# Altium schematic sheet External_Board_2mode.SchDoc
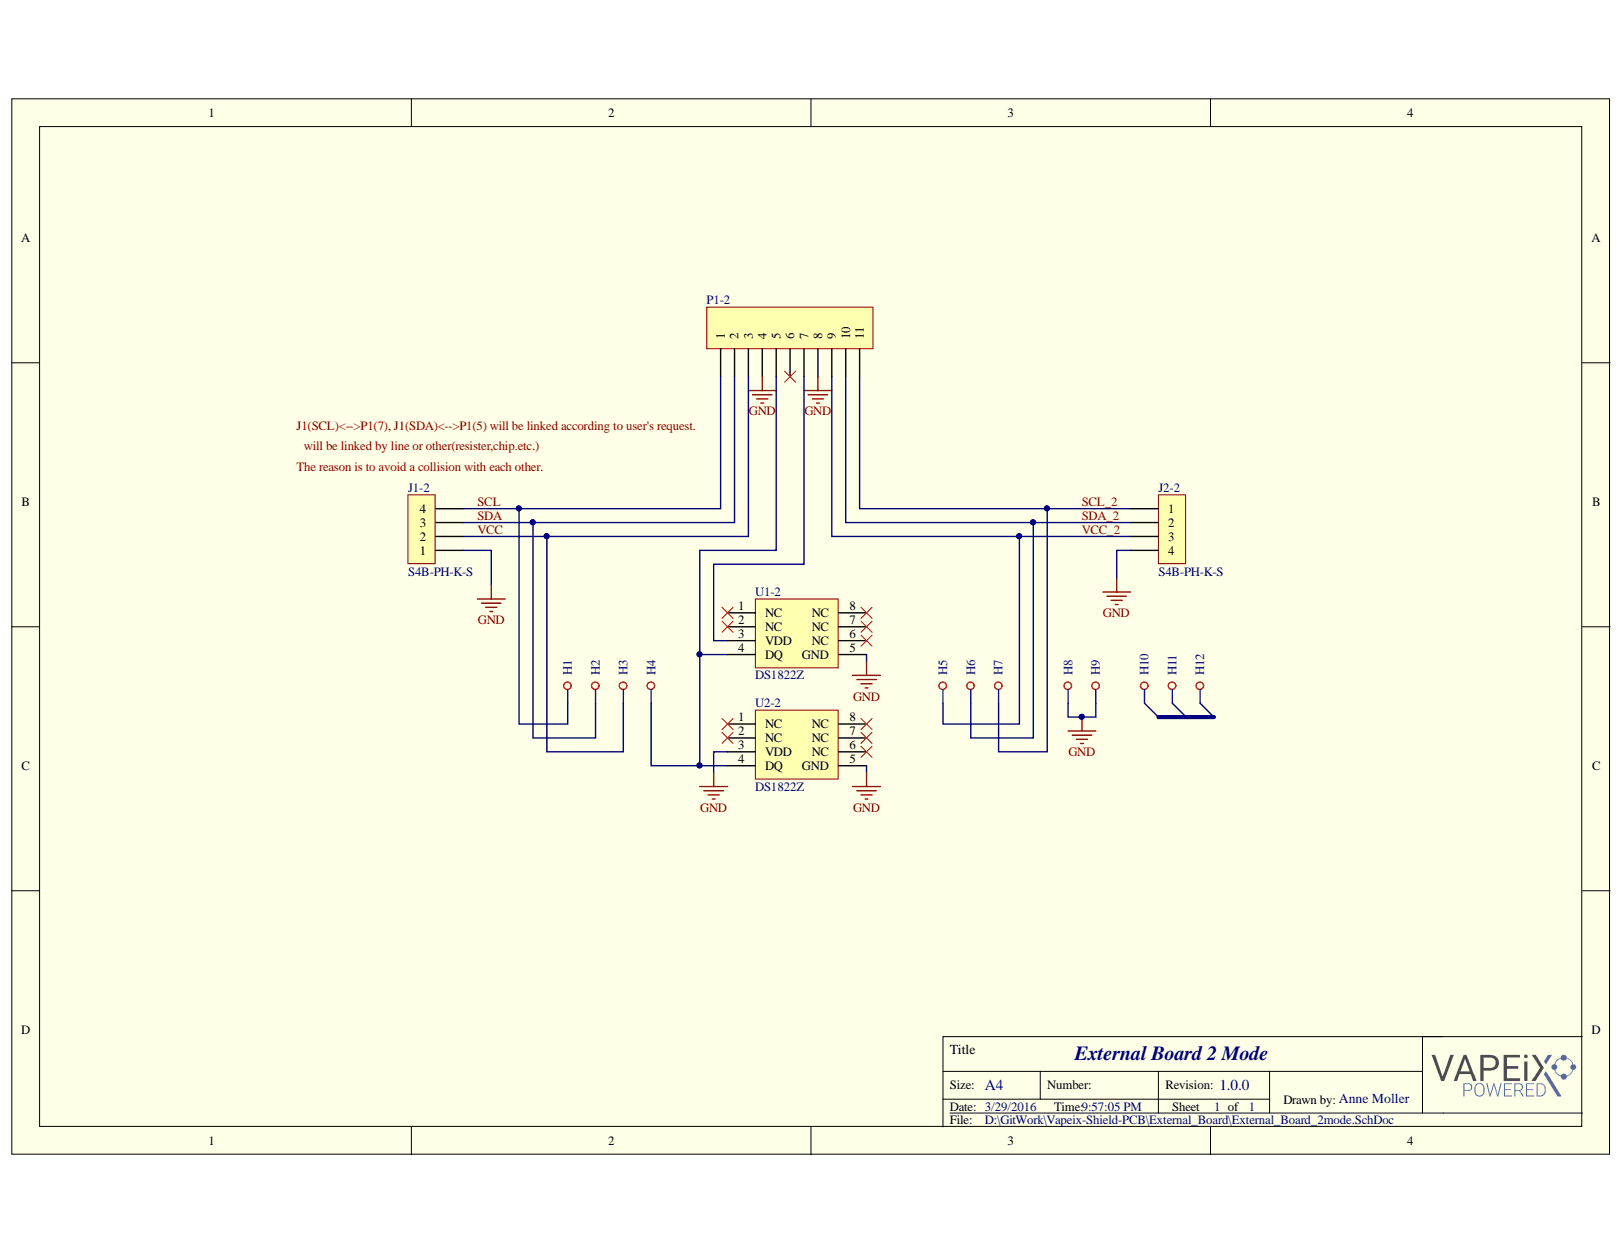
[diagram p0: External_Board_2mode.SchDoc]

## Parts
H1  TP_HOLE  [TestHole]  (value not drawn)
H2  TP_HOLE  [TestHole]  (value not drawn)
H3  TP_HOLE  [TestHole]  (value not drawn)
H4  TP_HOLE  [TestHole]  (value not drawn)
H5  TP_HOLE  [TestHole]  (value not drawn)
H6  TP_HOLE  [TestHole]  (value not drawn)
H7  TP_HOLE  [TestHole]  (value not drawn)
H8  TP_HOLE  [TestHole]  (value not drawn)
H9  TP_HOLE  [TestHole]  (value not drawn)
H10  TP_HOLE  [TestHole]  (value not drawn)
H11  TP_HOLE  [TestHole]  (value not drawn)
H12  TP_HOLE  [TestHole]  (value not drawn)
J1-2  S4B-PH-K-S  [CON4]
J2-2  S4B-PH-K-S  [CON4]
P1-2  Header11  [CON11]  (value not drawn)
U1-2  DS1822Z  [DS1822]
U2-2  DS1822Z  [DS1822]

## Nets
GND: H8.1 H9.1 J1-2.1 J2-2.4 P1-2.4 P1-2.8 U1-2.5(GND) U2-2.3(VDD) U2-2.5(GND)
SCL: H1.1 J1-2.4 P1-2.1
SCL_2: H7.1 J2-2.1 P1-2.11
SDA: H2.1 J1-2.3 P1-2.2
SDA_2: H6.1 J2-2.2 P1-2.10
VCC: H3.1 J1-2.2 P1-2.3
VCC_2: H5.1 J2-2.3 P1-2.9
NetH4_1: H4.1 P1-2.5 U1-2.4(DQ) U2-2.4(DQ)
NetP1-2_7: P1-2.7 U1-2.3(VDD)
J1(SCL)<-->P1(7), J1(SDA)<-->P1(5) WILL BE LINKED ACCORDING TO USER'S REQUEST.:
N00003:
THE REASON IS TO AVOID A COLLISION WITH EACH OTHER.:
WILL BE LINKED BY LINE OR OTHER(RESISTER,CHIP.ETC.):

Not connected: H10 1; H11 1; H12 1; P1-2 6; U1-2 1(NC) 2(NC) 6(NC) 7(NC) 8(NC); U2-2 1(NC) 2(NC) 6(NC) 7(NC) 8(NC)

## Library data of the parts
H1-H12 = TP_HOLE: TEST POINT HOLE; Component Kind: Standard; Library Name: ExternalLib.SchLib
J1-2 J2-2 = S4B-PH-K-S (LF)(SN): JST (JAPAN SOLDERLESS TERMINALS) - S4B-PH-K-S (LF)(SN) - CONNECTOR, HEADER, 2MM, 4 POSITION, 1ROW; Component Kind: Standard; ComponentLink1Description: http://www.farnell.com/datasheets/80564.pdf; ComponentLink1URL: http://www.farnell.com/datasheets/80564.pdf; Manufacturer: JST (JAPAN SOLDERLESS TERMINALS); Manufacturer Part Number: S4B-PH-K-S (LF)(SN); Pricing 1: 1=0.14, 250=0.126, 500=0.112, 1000=0.101, 5000=0.069 (USD); RoHS: YES; Stock 1: 908; Supplier 1: Newark; Library Name: ExternalLib.SchLib
P1-2 = SFH11-PBPC-D10-ST-BK: CONN HEADR FMALE 20POS .1" DL AU; Manufacturer: Sullins Connector Solutions; Connector Type: Header; Number of Positions: 20; Number of Positions Loaded: All; Pitch: 0.100" (2.54mm); Category: Connectors, Interconnects; Color: Black; Component Kind: Standard; ComponentLink1Description: http://sullinscorp.com/catalogs/146_PAGE119_.100_SFH11_SERIES_FEMALE_HDR_ST_RA.pdf; ComponentLink1URL: http://sullinscorp.com/catalogs/146_PAGE119_.100_SFH11_SERIES_FEMALE_HDR_ST_RA.pdf; Contact Finish: Gold; Contact Finish Thickness: Flash; Contact Type: Female Socket; Fastening Type: -; Features: Polarizing Key; Manufacturer Part Number: SFH11-PBPC-D10-ST-BK; Mounting Type: Through Hole; Number of Rows: 2; Packaging: Tray; Pricing 1: 1=1.43, 10=1.185, 25=1.0976, 50=0.9878, 100=0.878, 250=0.76824, 500=0.7024, 1000=0.63655, 2500=0.5707 (USD); RoHS: RoHS Compliant; Row Spacing: 0.100" (2.54mm); Stock 1: 14457; Supplier 1: Digi-Key; Termination: Solder; Library Name: ExternalLib.SchLib
U1-2 U2-2 = DS1822Z+: SENSOR TEMPERATURE 1-WIRE 8SOIC; Manufacturer: Maxim Integrated; Accuracy - Highest (Lowest): ±2°C (±3°C); Output Type: 1-Wire®; Resolution: 11 b; Sensing Temperature - Local: -55°C ~ 125°C; Sensor Type: Digital, Local; Test Condition: -10°C ~ 85°C (-55°C ~ 125°C); Voltage - Supply: 3 V ~ 5.5 V; Category: Sensors, Transducers; Component Kind: Standard; ComponentLink1Description: http://datasheets.maximintegrated.com/en/ds/DS1822.pdf; ComponentLink1URL: http://datasheets.maximintegrated.com/en/ds/DS1822.pdf; ComponentLink2Description: http://datasheets.maximintegrated.com/en/ds/DS1775-DS1775R.pdf; ComponentLink2URL: http://datasheets.maximintegrated.com/en/ds/DS1775-DS1775R.pdf; Features: Output Switch, Programmable Limit, Standby Mode; Manufacturer Part Number: DS1822Z+; Operating Temperature: -55°C ~ 125°C; Package / Case: 8-SOIC (0.154", 3.90mm Width); Packaging: Tube; Pricing 1: 1=2.4, 10=2.321 (USD); Pricing 2: 1=2.14, 10=2.015, 25=1.6116, 50=1.531, 100=1.4102, 250=1.33764, 500=1.28928 (USD); RoHS: RoHS Compliant; Sensing Temperature - Remote: -; Stock 1: 1532; Stock 2: 5995; Supplier 1: Digi-Key; Supplier 2: Digi-Key; Supplier Device Package: 8-SOIC; Library Name: ExternalLib.SchLib
